# Altium schematic sheet Sheet3.SchDoc(Sheet3)
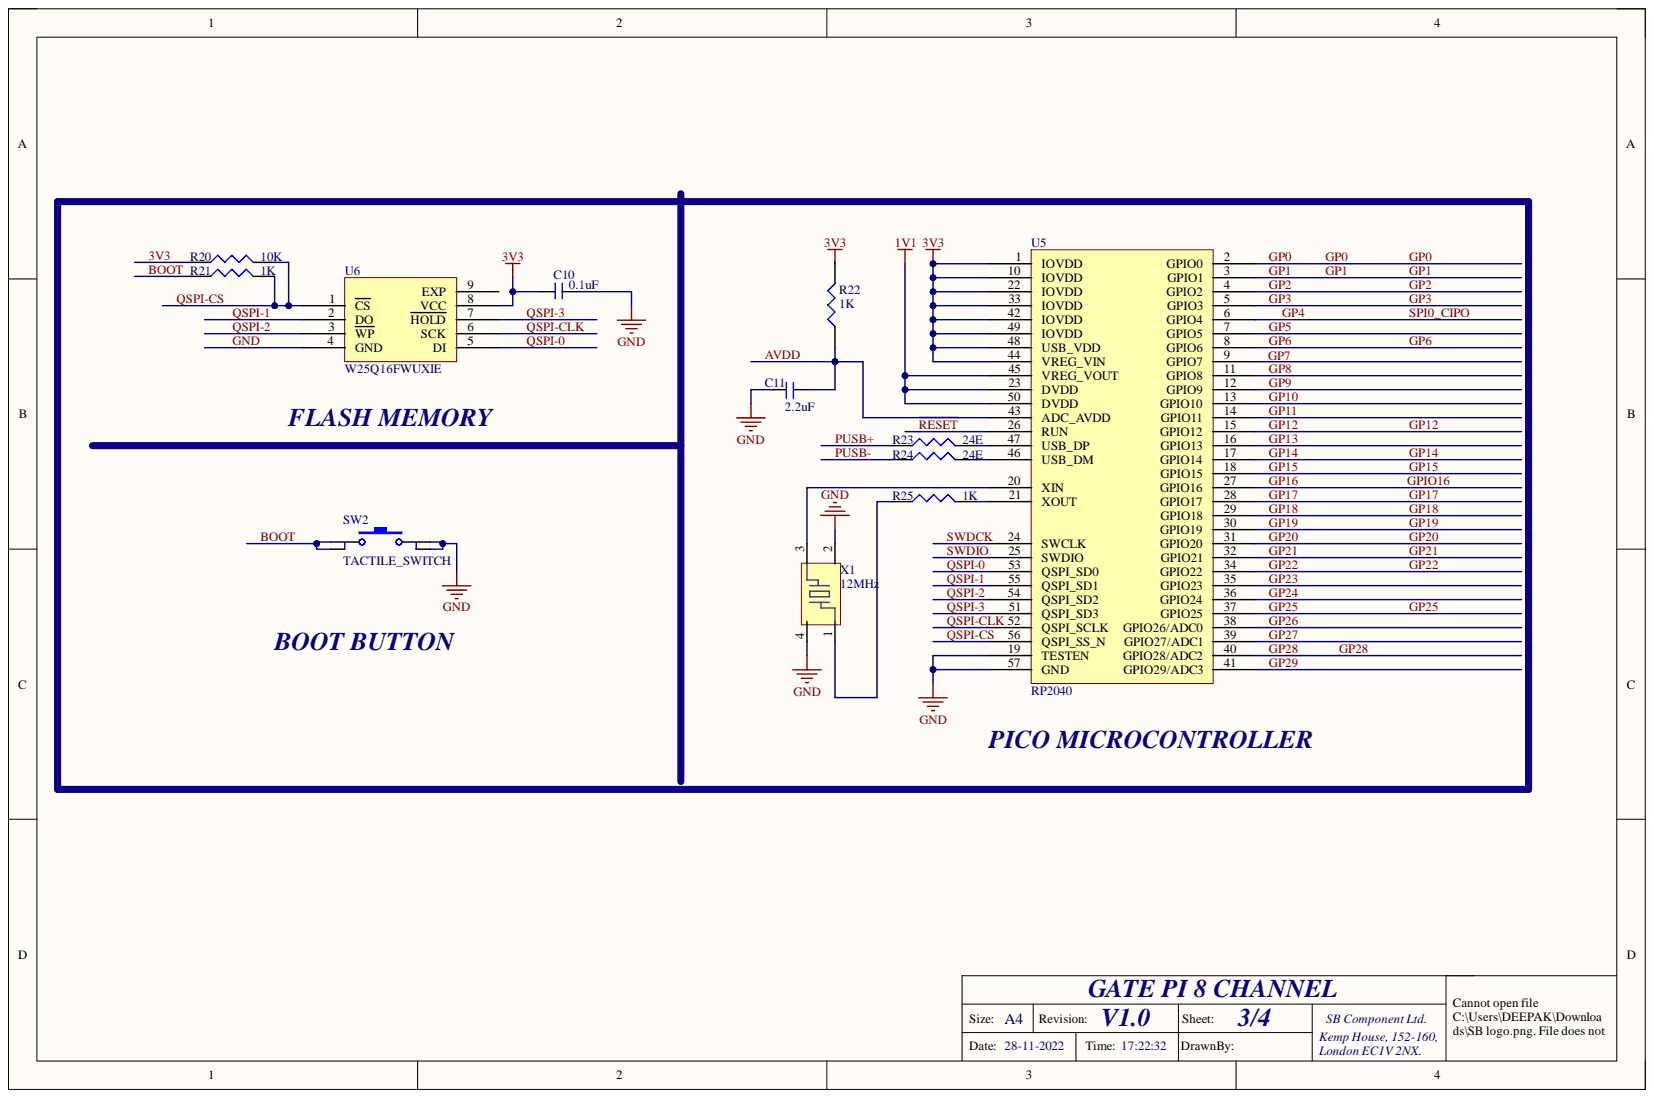
[diagram p0: Sheet3.SchDoc]

## Parts
C10  0.1uF  [CAP_0603_NONPOLAR]
C11  2.2uF  [CAP_0603_NONPOLAR]
R20  10K  [0603]
R21  1K  [0603]
R22  1K  [0603]
R23  24E  [0603]
R24  24E  [0603]
R25  1K  [0603]
SW2  TACTILE_SWITCH  [SKRPACE010]
U5  RP2040  [QFN-56]
U6  W25Q16FWUXIE  [USON-8 2X3MM]
X1  12MHz  [CRYSTAL OSC SMD]

## Nets
1V1: U5.23(DVDD) U5.45(VREG_VOUT) U5.50(DVDD)
3V3: C10.2 R20.1 R22.2 U5.1(IOVDD) U5.10(IOVDD) U5.22(IOVDD) U5.33(IOVDD) U5.42(IOVDD) U5.44(VREG_VIN) U5.48(USB_VDD) U5.49(IOVDD) U6.8(VCC)
AVDD: C11.2 R22.1 U5.43(ADC_AVDD)
BOOT: R21.1 SW2.1 SW2.2
GND: C10.1 C11.1 SW2.3 SW2.4 U5.19(TESTEN) U5.57(GND) U6.4(GND) X1.2 X1.4
GP0: U5.2(GPIO0)
GP1: U5.3(GPIO1)
GP2: U5.4(GPIO2)
GP3: U5.5(GPIO3)
GP5: U5.7(GPIO5)
GP6: U5.8(GPIO6)
GP8: U5.11(GPIO8)
GP9: U5.12(GPIO9)
GP10: U5.13(GPIO10)
GP11: U5.14(GPIO11)
GP12: U5.15(GPIO12)
GP13: U5.16(GPIO13)
GP14: U5.17(GPIO14)
GP15: U5.18(GPIO15)
GP16: U5.27(GPIO16)
GP17: U5.28(GPIO17)
GP18: U5.29(GPIO18)
GP19: U5.30(GPIO19)
GP20: U5.31(GPIO20)
GP21: U5.32(GPIO21)
GP22: U5.34(GPIO22)
GP23: U5.35(GPIO23)
GP24: U5.36(GPIO24)
GP25: U5.37(GPIO25)
GP26: U5.38(GPIO26/ADC0)
GP27: U5.39(GPIO27/ADC1)
GP28: U5.40(GPIO28/ADC2)
GP29: U5.41(GPIO29/ADC3)
PUSB+: R23.1
PUSB-: R24.1
QSPI-0: U5.53(QSPI_SD0) U6.5(DI)
QSPI-1: U5.55(QSPI_SD1) U6.2(DO)
QSPI-2: U5.54(QSPI_SD2) U6.3(WP)
QSPI-3: U5.51(QSPI_SD3) U6.7(HOLD)
QSPI-CLK: U5.52(QSPI_SCLK) U6.6(SCK)
QSPI-CS: R20.2 R21.2 U5.56(QSPI_SS_N) U6.1(CS)
~{RESET}: U5.26(RUN)
SPI0_CIPO: U5.6(GPIO4)
SWDCK: U5.24(SWCLK)
SWDIO: U5.25(SWDIO)
NetR23_2: R23.2 U5.47(USB_DP)
NetR24_2: R24.2 U5.46(USB_DM)
NetR25_1: R25.1 X1.1
NetR25_2: R25.2 U5.21(XOUT)
NetU5_20: U5.20(XIN) X1.3
GP4:
GP7:
GPIO16:

Not connected: U5 9(GPIO7); U6 9(EXP)

## Library data of the parts
C10 C11 = CAP_NONPOLAR: Component Kind: Standard; Library Name: dxp_pasl_lib.IntLib
R20-R25 = RES: Component Kind: Standard; Library Name: dxp_pasl_lib.IntLib
U5 = RP2040: A MICROCONTROLLER BY RPI; Component Kind: Standard; Library Name: dxp_pasl_lib.IntLib
U6 = W25Q16FWUXIE: FLASH - NOR USON-8_2x3mm ROHS #C401672; Component Kind: Standard; Library Name: dxp_pasl_lib.IntLib
X1 = CRYSTAL_OSCILATOR: 12MHz ±10ppm 12pF 80Ω SMD-3225_4P Crystals RoHS #C70565; Component Kind: Standard; Library Name: dxp_pasl_lib.IntLib
SW2 = TACTILE_SWITCH: Component Kind: Standard; Library Name: dxp_pasl_lib.IntLib
